# Altium schematic sheet Sheet2.SchDoc
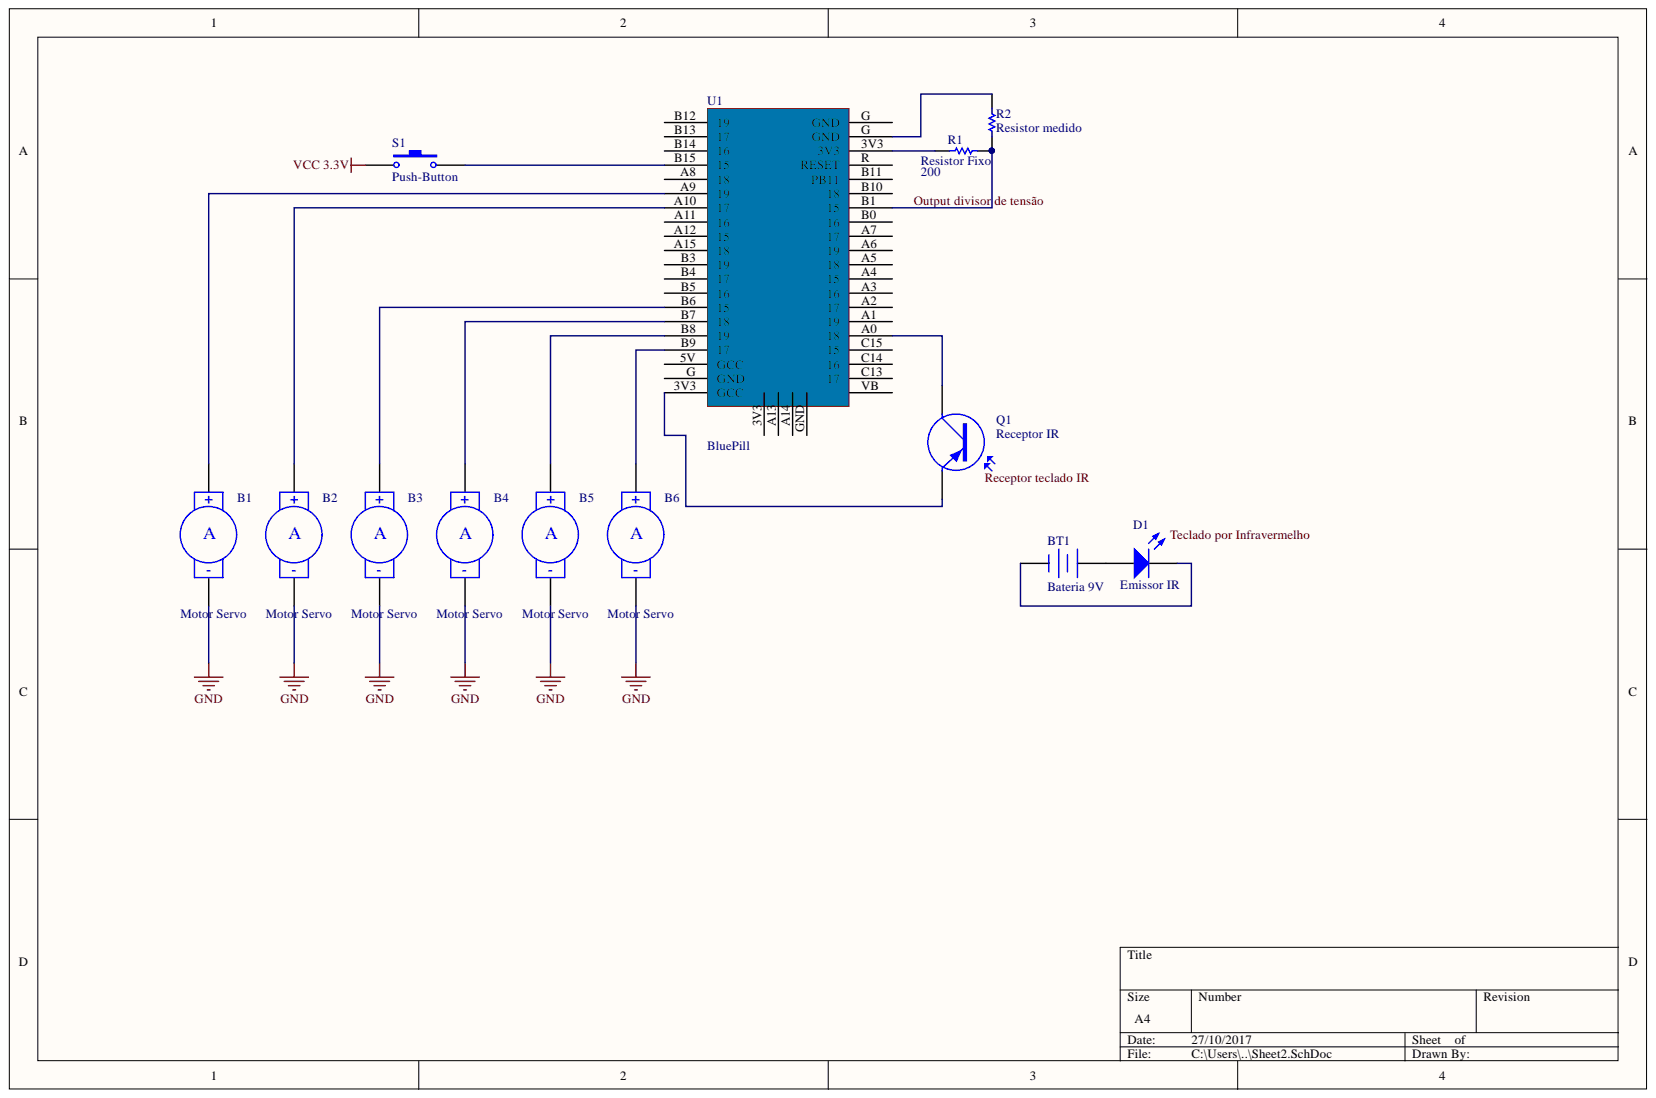
[diagram p0: Sheet2.SchDoc]

## Parts
B1  Motor Servo  [RAD-0.4]
B2  Motor Servo  [RAD-0.4]
B3  Motor Servo  [RAD-0.4]
B4  Motor Servo  [RAD-0.4]
B5  Motor Servo  [RAD-0.4]
B6  Motor Servo  [RAD-0.4]
BT1  Bateria 9V  [BAT-2]
D1  Emissor IR  [LED-1]
Q1  Receptor IR  [TO-220_A]
R1  Resistor Fixo  value 200  [AXIAL-0.3]
R2  Resistor medido  [AXIAL-0.3]
S1  Push-Button  [SPST-2]
U1  BluePill

## Nets
GND: B1.2 B2.2 B3.2 B4.2 B5.2 B6.2
VCC 3.3V: S1.1
Output divisor de tensão: R1.2 R2.1 U1.B1
NetB1_1: B1.1 U1.A9
NetB2_1: B2.1 U1.A10
NetB3_1: B3.1 U1.B6
NetB4_1: B4.1 U1.B7
NetB5_1: B5.1 U1.B8
NetB6_1: B6.1 U1.B9
NetBT1_1: BT1.1 D1.1
NetBT1_2: BT1.2 D1.2
NetQ1_1: Q1.1 U1.A0
NetQ1_3: Q1.3 U1.3V3
NetR1_1: R1.1 U1.3V3
NetR2_2: R2.2 U1.G
NetS1_2: S1.2 U1.B15
Receptor teclado IR:
Teclado por Infravermelho:

Not connected: U1 5V(GCC) A1 A2 A3 A4 A5 A6 A7 A8 A11 A12 A13 A14 A15 B0 B3 B4 B5 B10 B11(PB11) B12 B13 B14 C13 C14 C15 GND R(RESET) VB

## Library data of the parts
B1-B6 = Motor Servo: Servo Motor; Component Kind: Standard; LatestRevisionDate: 17-Jul-2002; LatestRevisionNote: Re-released for DXP Platform.; Library Name: Miscellaneous Devices.IntLib; PackageDescription: Radial Cap, Thru-Hole; 2 Leads; 0.4 in Pin Spacing; PackageReference: RAD-0.4; Published: 24-Mar-1999; Publisher: Altium Limited
BT1 = Battery: Multicell Battery; Component Kind: Standard; LatestRevisionDate: 17-Jul-2002; LatestRevisionNote: Re-released for DXP Platform.; Library Name: Miscellaneous Devices.IntLib; PackageDescription: Battery; 2 Leads; PackageReference: BAT-2; Published: 24-Mar-1999; Publisher: Altium Limited
D1 = LED1: Typical RED GaAs LED; Component Kind: Standard; LatestRevisionDate: 08-Jul-2005; LatestRevisionNote: Mech Layer 1 removed.; Library Name: Miscellaneous Devices.IntLib; PackageDescription: LED; 2 Leads; PackageReference: LED-1; Published: 8-Jun-2000; Simulation: LED1; Publisher: Altium Limited
Q1 = Photo PNP: PNP Phototransistor; Code_JEDEC: TO-220-AC; Component Kind: Standard; LatestRevisionDate: 17-Jul-2002; LatestRevisionNote: Re-released for DXP Platform.; Library Name: Miscellaneous Devices.IntLib; PackageReference: TO-220_A; Published: 24-Mar-1999; Publisher: Altium Limited
R1 R2 = Res1: Resistor; Component Kind: Standard; LatestRevisionDate: 17-Jul-2002; LatestRevisionNote: Re-released for DXP Platform.; Library Name: Miscellaneous Devices.IntLib; PackageDescription: Axial Device, Thru-Hole; 2 Leads; 0.3 in Pin Spacing; PackageReference: AXIAL-0.3; Published: 8-Jun-2000; Signal Integrity: Res; Simulation: RESISTOR; Publisher: Altium Limited
S1 = SW-PB: Switch; Component Kind: Standard; LatestRevisionDate: 17-Jul-2002; LatestRevisionNote: Re-released for DXP Platform.; Library Name: Miscellaneous Devices.IntLib; PackageDescription: Switch; 2 Leads; PackageReference: SPST-2; Published: 24-Mar-1999; Publisher: Altium Limited
U1 = BluePill: Component Kind: Standard; Library Name: lib2.SchLib
